# Altium schematic sheet MIPI.SchDoc(MIPI)
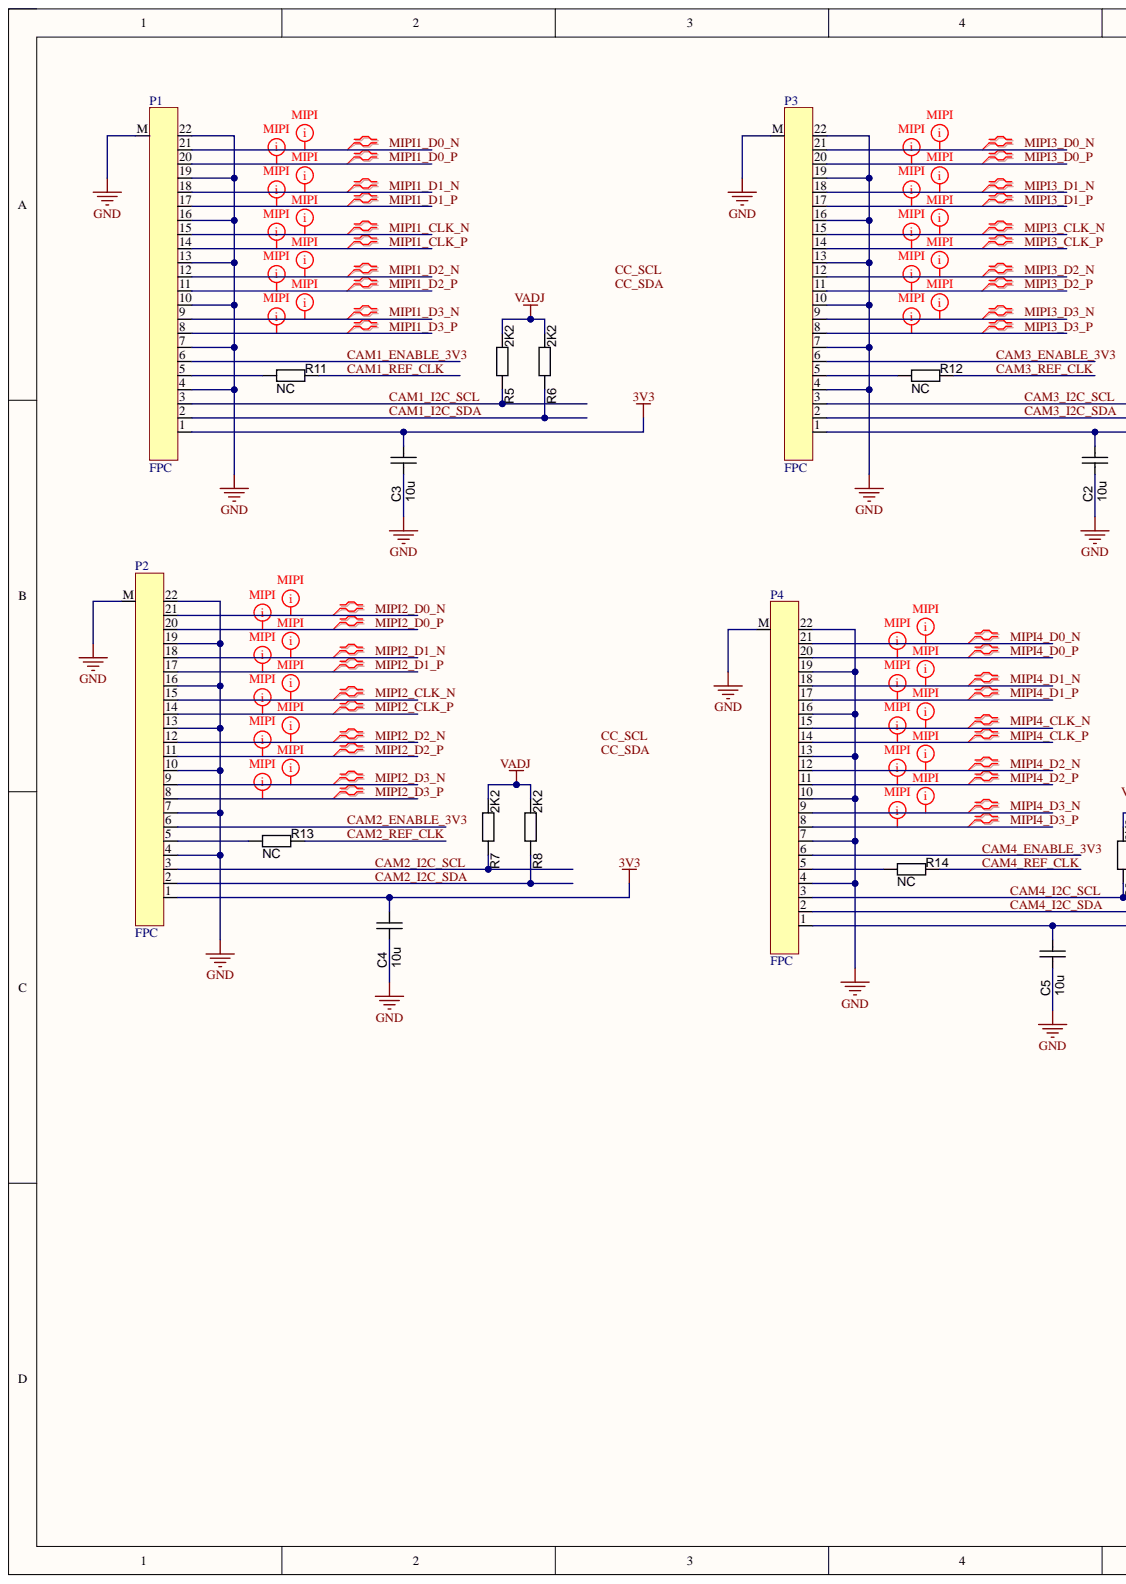
[diagram p0: MIPI.SchDoc, left]
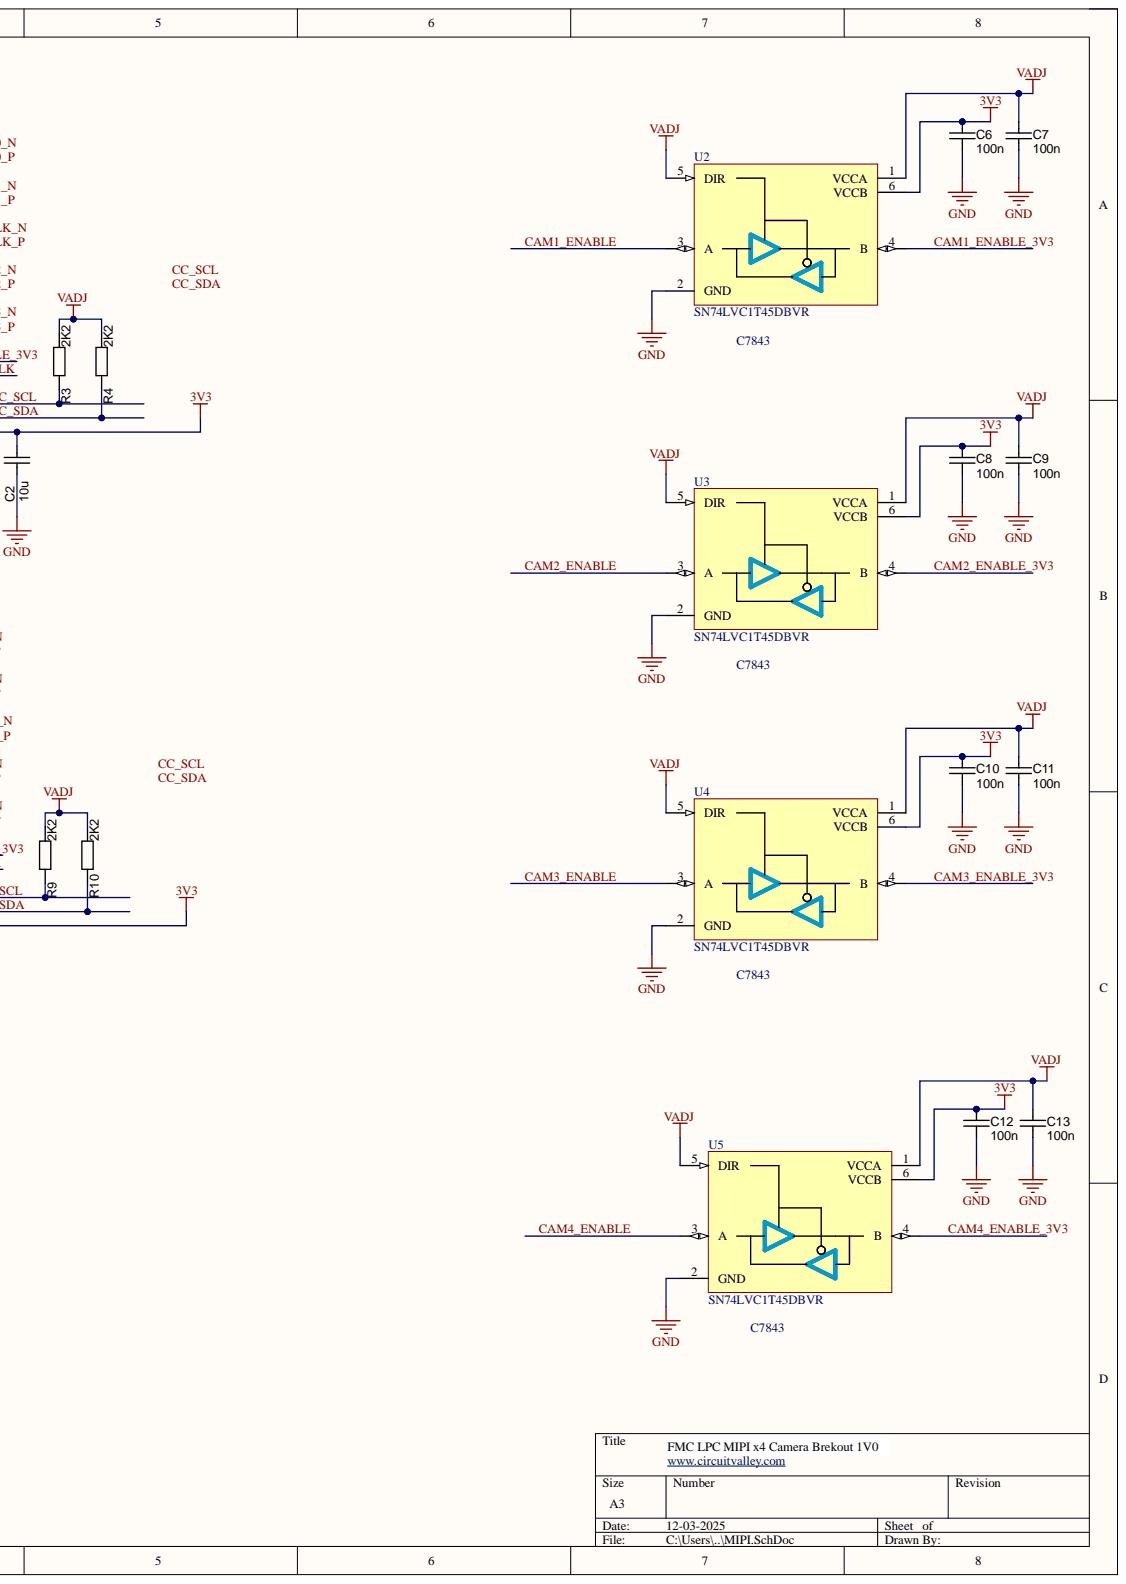
[diagram p1: MIPI.SchDoc, right]

## Parts
C2  value 10u  [CAPC1608N]  (hidden comment: approved sub OK)
C3  value 10u  [CAPC1608N]  (hidden comment: approved sub OK)
C4  value 10u  [CAPC1608N]  (hidden comment: approved sub OK)
C5  value 10u  [CAPC1608N]  (hidden comment: approved sub OK)
C6  100n  [CAPC1005N]
C7  100n  [CAPC1005N]
C8  100n  [CAPC1005N]
C9  100n  [CAPC1005N]
C10  100n  [CAPC1005N]
C11  100n  [CAPC1005N]
C12  100n  [CAPC1005N]
C13  100n  [CAPC1005N]
P1  FPC  [AFC11-S22ICA-00]
P2  FPC  [AFC11-S22ICA-00]
P3  FPC  [AFC11-S22ICA-00]
P4  FPC  [AFC11-S22ICA-00]
R3  value 2K2  [RESC1005N]  (hidden comment: Thick Film)
R4  value 2K2  [RESC1005N]  (hidden comment: Thick Film)
R5  value 2K2  [RESC1005N]  (hidden comment: Thick Film)
R6  value 2K2  [RESC1005N]  (hidden comment: Thick Film)
R7  value 2K2  [RESC1005N]  (hidden comment: Thick Film)
R8  value 2K2  [RESC1005N]  (hidden comment: Thick Film)
R9  value 2K2  [RESC1005N]  (hidden comment: Thick Film)
R10  value 2K2  [RESC1005N]  (hidden comment: Thick Film)
R11  value NC  [RESC1005N]  (hidden comment: Thick Film)
R12  value NC  [RESC1005N]  (hidden comment: Thick Film)
R13  value NC  [RESC1005N]  (hidden comment: Thick Film)
R14  value NC  [RESC1005N]  (hidden comment: Thick Film)
U2  SN74LVC1T45DBVR  [SOT23-6]
U3  SN74LVC1T45DBVR  [SOT23-6]
U4  SN74LVC1T45DBVR  [SOT23-6]
U5  SN74LVC1T45DBVR  [SOT23-6]

## Nets
3V3: C2.1 C3.1 C4.1 C5.1 C6.2 C8.2 C10.2 C12.2 P1.1 P2.1 P3.1 P4.1 U2.6(VCCB) U3.6(VCCB) U4.6(VCCB) U5.6(VCCB)
GND: C2.2 C3.2 C4.2 C5.2 C6.1 C7.1 C8.1 C9.1 C10.1 C11.1 C12.1 C13.1 P1.4 P1.7 P1.10 P1.13 P1.16 P1.19 P1.22 P1.M P2.4 P2.7 P2.10 P2.13 P2.16 P2.19 P2.22 P2.M P3.4 P3.7 P3.10 P3.13 P3.16 P3.19 P3.22 P3.M P4.4 P4.7 P4.10 P4.13 P4.16 P4.19 P4.22 P4.M U2.2(GND) U3.2(GND) U4.2(GND) U5.2(GND)
VADJ: C7.2 C9.2 C11.2 C13.2 R3.1 R4.1 R5.1 R6.1 R7.1 R8.1 R9.1 R10.1 U2.1(VCCA) U2.5(DIR) U3.1(VCCA) U3.5(DIR) U4.1(VCCA) U4.5(DIR) U5.1(VCCA) U5.5(DIR)
CAM1_ENABLE: U2.3(A)
CAM1_ENABLE_3V3: P1.6 U2.4(B)
CAM1_I2C_SCL: P1.3 R5.2
CAM1_I2C_SDA: P1.2 R6.2
CAM1_REF_CLK: R11.2
CAM2_ENABLE: U3.3(A)
CAM2_ENABLE_3V3: P2.6 U3.4(B)
CAM2_I2C_SCL: P2.3 R7.2
CAM2_I2C_SDA: P2.2 R8.2
CAM2_REF_CLK: R13.2
CAM3_ENABLE: U4.3(A)
CAM3_ENABLE_3V3: P3.6 U4.4(B)
CAM3_I2C_SCL: P3.3 R3.2
CAM3_I2C_SDA: P3.2 R4.2
CAM3_REF_CLK: R12.2
CAM4_ENABLE: U5.3(A)
CAM4_ENABLE_3V3: P4.6 U5.4(B)
CAM4_I2C_SCL: P4.3 R9.2
CAM4_I2C_SDA: P4.2 R10.2
CAM4_REF_CLK: R14.2
MIPI1_CLK_N: P1.15
MIPI1_CLK_P: P1.14
MIPI1_D0_N: P1.21
MIPI1_D0_P: P1.20
MIPI1_D1_N: P1.18
MIPI1_D1_P: P1.17
MIPI1_D2_N: P1.12
MIPI1_D2_P: P1.11
MIPI1_D3_N: P1.9
MIPI1_D3_P: P1.8
MIPI2_CLK_N: P2.15
MIPI2_CLK_P: P2.14
MIPI2_D0_N: P2.21
MIPI2_D0_P: P2.20
MIPI2_D1_N: P2.18
MIPI2_D1_P: P2.17
MIPI2_D2_N: P2.12
MIPI2_D2_P: P2.11
MIPI2_D3_N: P2.9
MIPI2_D3_P: P2.8
MIPI3_CLK_N: P3.15
MIPI3_CLK_P: P3.14
MIPI3_D0_N: P3.21
MIPI3_D0_P: P3.20
MIPI3_D1_N: P3.18
MIPI3_D1_P: P3.17
MIPI3_D2_N: P3.12
MIPI3_D2_P: P3.11
MIPI3_D3_N: P3.9
MIPI3_D3_P: P3.8
MIPI4_CLK_N: P4.15
MIPI4_CLK_P: P4.14
MIPI4_D0_N: P4.21
MIPI4_D0_P: P4.20
MIPI4_D1_N: P4.18
MIPI4_D1_P: P4.17
MIPI4_D2_N: P4.12
MIPI4_D2_P: P4.11
MIPI4_D3_N: P4.9
MIPI4_D3_P: P4.8
NetP1_5: P1.5 R11.1
NetP2_5: P2.5 R13.1
NetP3_5: P3.5 R12.1
NetP4_5: P4.5 R14.1
CC_SCL:
CC_SDA:

## Library data of the parts
C2-C5 = CAPC_560p_0603_5%: Capacitor SMD Cer 560pF 5% 50V NP0 0603; Manufacturer 1: AVX Corporation; Manufacturer Part Number 1: 06035A561JAT2A; Capacitance: 470pF; Material: ceramic; Ratings: 50V; NP0; Applications: General Purpose; Component Kind: Standard; ComponentLink1Description: http://www.avx.com/docs/Catalogs/ccog.pdf; ComponentLink1URL: http://www.avx.com/docs/Catalogs/ccog.pdf; Height - Seated (Max): -; Lead Spacing: -; Lead Style: -; Library Name: PBL - Capacitors.SCHLIB; Mounting Type: Surface Mount, MLCC; Operating Temperature: -55°C ~ 125°C; Package / Case: 0603 (1608 metric); Size / Dimension: 0.063" L x 0.032" W (1.60mm x 0.81mm); Supplier 1: Digi-Key; Supplier Part Number 1: 478-1184-1-ND; Thickness (Max): 0.035" (0.90mm); Voltage - Rated: 50V
C6-C13 = CAPC_10n_0402_16V_10%: Capacitor SMD Cer 10nF 10% 16V X7R 0402; Manufacturer 1: AVX Corporation; Manufacturer Part Number 1: 0402YC103KAT2A; Ratings: 16V; X7R; Component Kind: Standard; ComponentLink1Description: http://www.avx.com/docs/Catalogs/cx7r.pdf; ComponentLink1URL: http://www.avx.com/docs/Catalogs/cx7r.pdf; ComponentLink2Description: http://www.yageo.com/documents/recent/UPY-GPHC_X7R_6.3V-to-50V_8.pdf; ComponentLink2URL: http://www.yageo.com/documents/recent/UPY-GPHC_X7R_6.3V-to-50V_8.pdf; Library Name: PBL - Capacitors.SCHLIB; Manufacturer 2: Yageo; Manufacturer Part Number 2: CC0402KRX7R7BB103; Operating Temperature: -55°C ~ 125°C; Package / Case: 0402 (1005 Metric); Size / Dimension: 0.039" L x 0.020" W (1.00mm x 0.50mm); Supplier 1: Digi-Key; Supplier 2: Digi-Key; Supplier Part Number 1: 478-1114-1-ND; Supplier Part Number 2: 311-1042-1-ND; Tolerance: ±10%; Value: 100n
P1-P4 = FPC22_PIN: FPC 22 Pin; Component Kind: Standard; Library Name: cvlib.SchLib
R3-R14 = RES_3K3_0402_5%: Resistor SMD chip 3.3k Ohm 0.063W 5% 0402; Manufacturer 1: Yageo; Manufacturer Part Number 1: RC0402JR-073K3L; Ratings: 1/16 W; Component Kind: Standard; ComponentLink1Description: http://www.yageo.com/documents/recent/PYu-RC0402_51_RoHS_L_6.pdf; ComponentLink1URL: http://www.yageo.com/documents/recent/PYu-RC0402_51_RoHS_L_6.pdf; Library Name: PBL - Resistors.SCHLIB; Material: Thick Film; Package / Case: 0402 (1005 metric); Supplier 1: Digi-Key; Supplier Part Number 1: 311-3.3KJRCT-ND; Tolerance: ±5%
U2-U5 = SN74LVC1T45DBVR: Single Bit Level Translation; Component Kind: Standard; Library Name: cvlib.SchLib
